# Altium schematic sheet Hub.SchDoc
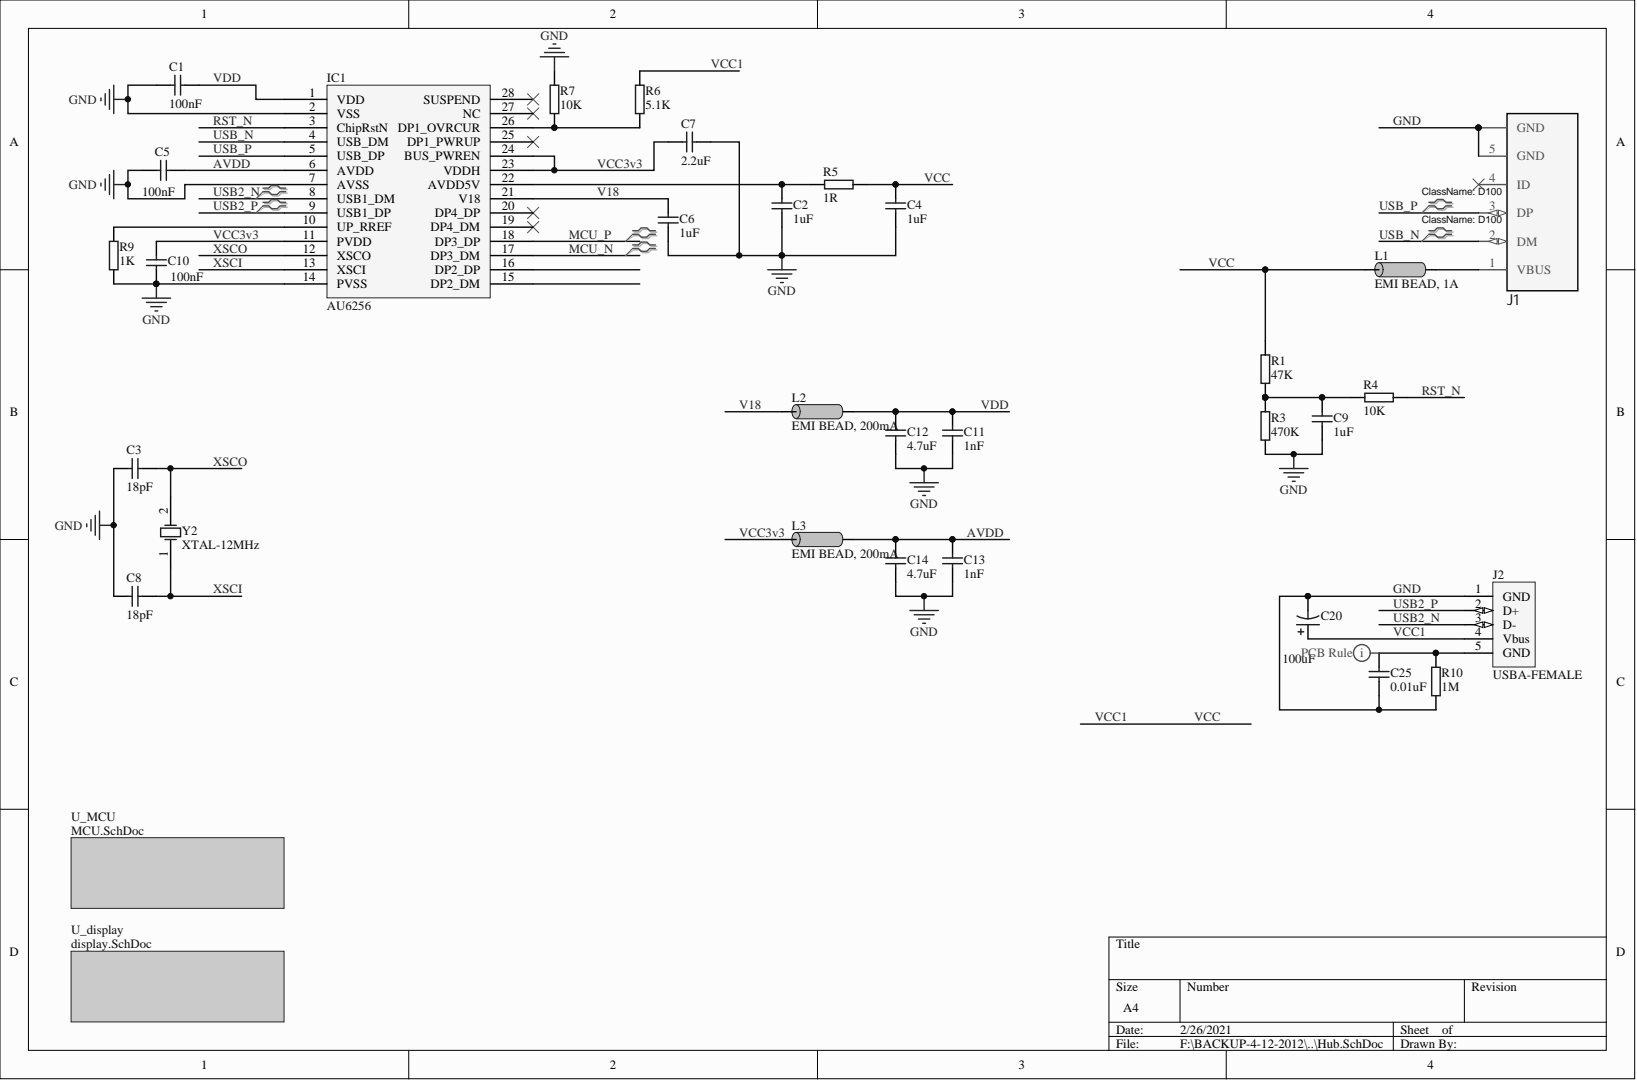
[diagram p0: Hub.SchDoc]

## Parts
C1  value 100nF  [CAPC1608N]  (hidden comment: CAP-NP)
C2  value 1uF  [CAPC1608N]  (hidden comment: CAP-NP)
C3  value 18pF  [CAPC1608N]  (hidden comment: CAP-NP)
C4  value 1uF  [CAPC1608N]  (hidden comment: CAP-NP)
C5  value 100nF  [CAPC1608N]  (hidden comment: CAP-NP)
C6  value 1uF  [CAPC1608N]  (hidden comment: CAP-NP)
C7  value 2.2uF  [CAPC1608N]  (hidden comment: CAP-NP)
C8  value 18pF  [CAPC1608N]  (hidden comment: CAP-NP)
C9  value 1uF  [CAPC1608N]  (hidden comment: CAP-NP)
C10  value 100nF  [CAPC1608N]  (hidden comment: CAP-NP)
C11  value 1nF  [CAPC1608N]  (hidden comment: CAP-NP)
C12  value 4.7uF  [CAPC2012N]  (hidden comment: CAP-NP)
C13  value 1nF  [CAPC1608N]  (hidden comment: CAP-NP)
C14  value 4.7uF  [CAPC2012N]  (hidden comment: CAP-NP)
C20  value 100uF  [ELCO_8x6]  (hidden comment: Cap Pol3)
C25  0.01uF  [CAPC3216N]
IC1  AU6256  [AU6256]
J1  CON_USB_micro_AB  [CON_USB_micro_AB]  (value not drawn)
J2  USBA-FEMALE  [USBA-FEMALE_SMT]
L1  value EMI BEAD, 1A  [CAPC1608N]  (hidden comment: INDUCTOR-0603)
L2  value EMI BEAD, 200mA  [CAPC1608N]  (hidden comment: INDUCTOR-0603)
L3  value EMI BEAD, 200mA  [CAPC1608N]  (hidden comment: INDUCTOR-0603)
R1  value 47K  [RESC1609N]  (hidden comment: RES)
R3  value 470K  [RESC1609N]  (hidden comment: RES)
R4  value 10K  [RESC1609N]  (hidden comment: RES)
R5  value 1R  [RESC1609N]  (hidden comment: RES)
R6  value 5.1K  [RESC1609N]  (hidden comment: RES)
R7  value 10K  [RESC1609N]  (hidden comment: RES)
R9  value 1K  [RESC1609N]  (hidden comment: RES)
R10  1M  [RESC3216N]
Y2  XTAL-12MHz  [XTAL-HC49-THSMD1]

## Nets
AVDD: C5.2 C13.1 C14.1 IC1.6(AVDD) L3.2
GND: C1.1 C2.2 C3.1 C4.2 C5.1 C6.2 C7.2 C8.1 C9.2 C10.2 C11.2 C12.2 C13.2 C14.2 C20.2 C25.2 IC1.2(VSS) IC1.7(AVSS) IC1.14(PVSS) J1.5(GND) J1.GND J2.1(GND) R3.1 R7.2 R9.1 R10.2
MCU_N: IC1.17(DP3_DM)
MCU_P: IC1.18(DP3_DP)
RST_N: IC1.3(ChipRstN) R4.2
USB2_N: IC1.8(USB1_DM) J2.3(D-)
USB2_P: IC1.9(USB1_DP) J2.2(D+)
USB_N: IC1.4(USB_DM) J1.2(DM)
USB_P: IC1.5(USB_DP) J1.3(DP)
V18: C6.1 IC1.21(V18) L2.1
VCC: C4.1 C20.1 J2.4(Vbus) L1.1 R1.2 R5.2 R6.2
VCC3V3: C7.1 C10.1 IC1.11(PVDD) IC1.23(VDDH) IC1.24(BUS_PWREN) L3.1
VDD: C1.2 C11.1 C12.1 IC1.1(VDD) L2.2
XSCI: C8.2 IC1.13(XSCI) Y2.1
XSCO: C3.2 IC1.12(XSCO) Y2.2
NetC2_1: C2.1 IC1.22(AVDD5V) R5.1
NetC9_1: C9.1 R1.1 R3.2 R4.1
NetC25_1: C25.1 J2.5(GND) R10.1
NetIC1_10: IC1.10(UP_RREF) R9.2
NetIC1_15: IC1.15(DP2_DM)
NetIC1_16: IC1.16(DP2_DP)
NetIC1_19: IC1.19(DP4_DM)
NetIC1_20: IC1.20(DP4_DP)
NetIC1_25: IC1.25(DP1_PWRUP)
NetIC1_26: IC1.26(DP1_OVRCUR) R6.1 R7.1
NetIC1_27: IC1.27(NC)
NetIC1_28: IC1.28(SUSPEND)
NetJ1_1: J1.1(VBUS) L1.2
NetJ1_4: J1.4(ID)

## Library data of the parts
C1-C11 C13 = 1uF: Database Table Name: C; Component Kind: Standard; Library Name: trioflex.DbLib
C12 C14 = C0805: Database Table Name: C; Component Kind: Standard; Library Name: trioflex.DbLib
C20 = Cap Pol3: Polarized Capacitor (Surface Mount); Component Kind: Standard; LatestRevisionDate: 29-May-2009; LatestRevisionNote: IPC-7351 Footprint Added.; Library Name: Hub.SCHLIB; PackageDescription: Chip Capacitor; PackageReference: C0805; Published: 8-Jun-2000; Signal Integrity: Cap Pol; Simulation: CAP; Publisher: Altium Limited
C25 = 22679: Bezeichnung: KON 100pF; Database Table Name: Capacitor_; FABKEY: A3C40022151; Value: 0.01uF, 500V; AN: 22679; Case: 3216 (1206); Component Kind: Standard; Library Name: dbww.dblib
IC1 = AU6256: Database Table Name: IC; ID: 39; Component: AU6256; Component Kind: Standard; Description: AU6256; Value: AU6256; Library Name: trioflex.DbLib
J1 = CON_USB_micro_AB: 5-pin Female SMT USB mini-AB (OTG) Receptacle Molex; Database Table Name: CONN; ID: 33; Component: CON_USB_micro_AB; Component Kind: Standard; Value: CON_USB_micro_AB; Library Name: trioflex.DbLib
J2 = USBA-FEMALE: Database Table Name: CONN; ID: 32; Component: USBA-FEMALE; Component Kind: Standard; Value: USBA-FEMALE; Library Name: trioflex.DbLib
L1 = INDUCTOR-0603: Database Table Name: MISC; ID: 9; Component: INDUCTOR-0603; Component Kind: Standard; Description: INDUCTOR-0603; Library Name: trioflex.DbLib
L2 L3 = INDUCTOR-0603: Database Table Name: MISC; ID: 9; Value: EMI BEAD, 200mA; Component: INDUCTOR-0603; Component Kind: Standard; Description: INDUCTOR-0603; Library Name: trioflex.DbLib
R1 R3-R7 R9 = R0603: Database Table Name: R; ID: 9; Component: R0603; Component Kind: Standard; Library Name: trioflex.DbLib
R10 = 22757: Bezeichnung: WID SMD 1M; Database Table Name: Resistor_; FABKEY: 01810002607; Value: 1M, 500V; AN: 22757; Case: 3216 (1206); Component Kind: Standard; Library Name: dbww.dblib
Y2 = XTAL-HC49-THSMD1: Crystal Oscillator; Database Table Name: Q; ID: 12; Component: XTAL-HC49-THSMD1; Component Kind: Standard; Value: XTAL-HC49-THSMD1; Library Name: trioflex.DbLib
